# Altium schematic sheet MCU.SchDoc
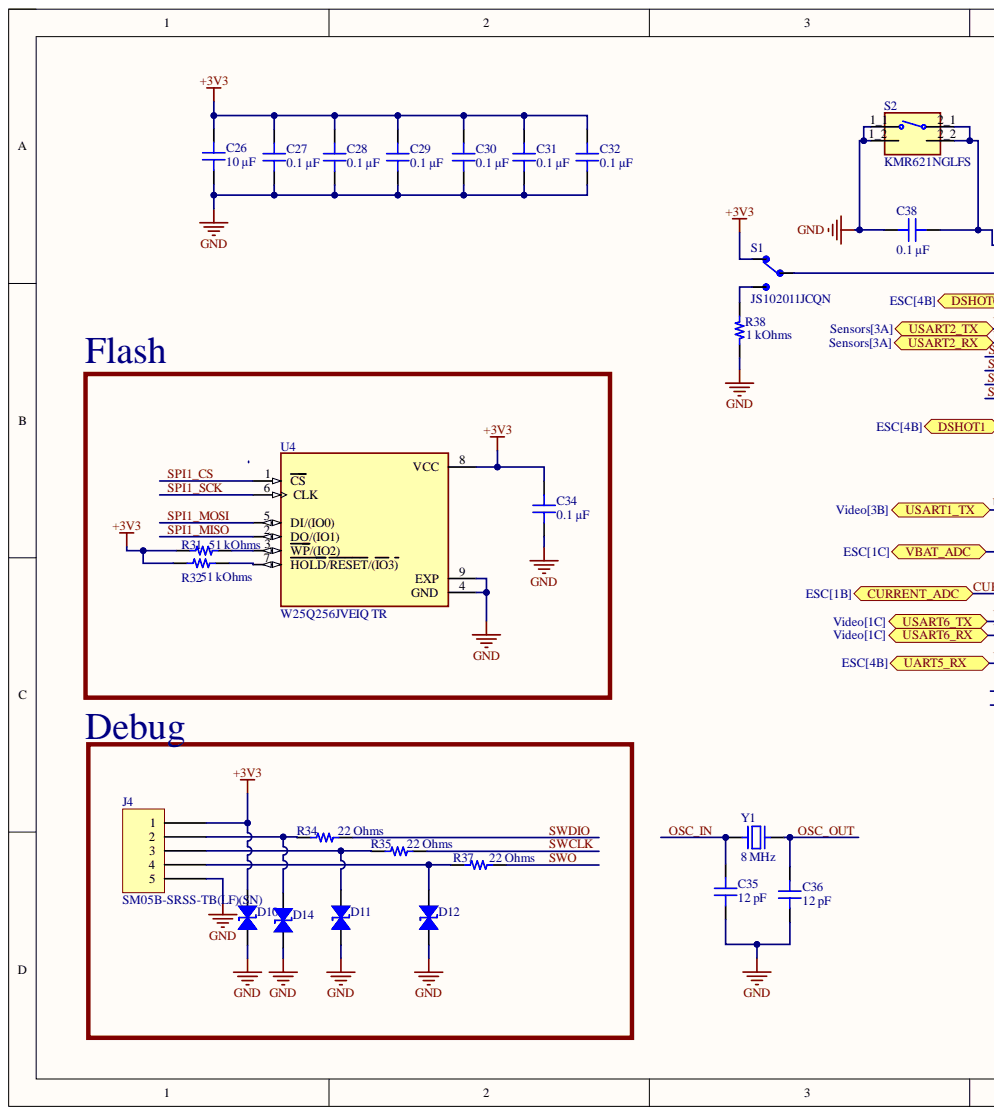
[diagram p0: MCU.SchDoc, left]
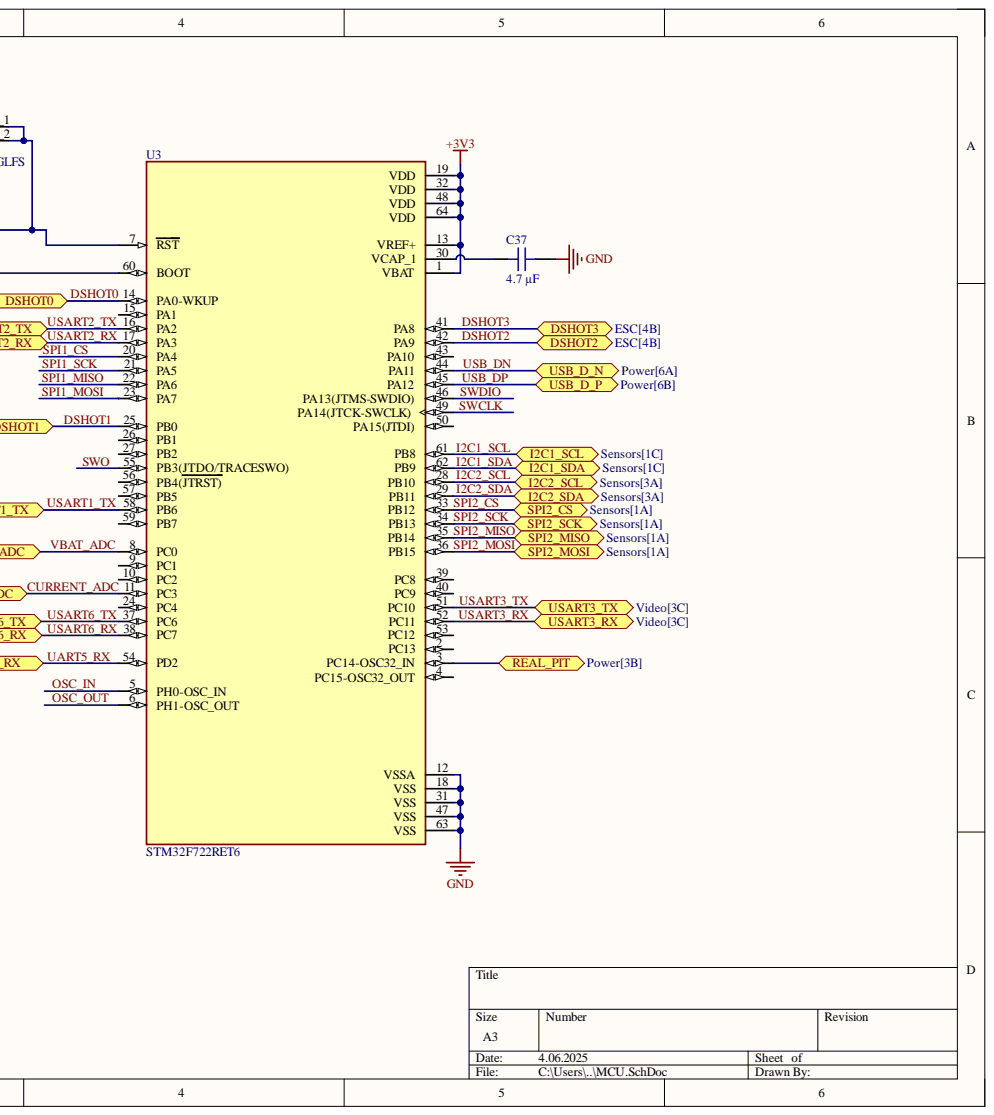
[diagram p1: MCU.SchDoc, right]

## Parts
C26  10 µF  [CAP 0402_1005]
C27  0.1 µF  [CAP 0402_1005]
C28  0.1 µF  [CAP 0402_1005]
C29  0.1 µF  [CAP 0402_1005]
C30  0.1 µF  [CAP 0402_1005]
C31  0.1 µF  [CAP 0402_1005]
C32  0.1 µF  [CAP 0402_1005]
C34  0.1 µF  [CAP 0603_1608]
C35  12 pF  [CAP 0402_1005]
C36  12 pF  [CAP 0402_1005]
C37  4.7 µF  [CAP 0402_1005]
C38  0.1 µF  [CAP 0402_1005]
D10  DESD5V0U1BA-7  [SOD323]  (value not drawn)
D11  DESD5V0U1BA-7  [SOD323]  (value not drawn)
D12  DESD5V0U1BA-7  [SOD323]  (value not drawn)
D14  DESD5V0U1BA-7  [SOD323]  (value not drawn)
J4  SM05B-SRSS-TB(LF)(SN)  [JST SM05B-SRSS-TB]
R31  51 kOhms  [RES 0603_1608]
R32  51 kOhms  [RES 0603_1608]
R34  22 Ohms  [YAGEO RES 0402_1005]
R35  22 Ohms  [YAGEO RES 0402_1005]
R37  22 Ohms  [YAGEO RES 0402_1005]
R38  1 kOhms  [YAGEO RES 0402_1005]
S1  JS102011JCQN  [JS102011JCQN]
S2  KMR621NGLFS  [KMR621NGLFS]
U3  STM32F722RET6  [STM32F722RET6]
U4  W25Q256JVEIQ TR  [W25Q256JVEIQ TR]
Y1  8 MHz  [NDK NX3225GD]

## Ports
CURRENT_ADC, DSHOT0, DSHOT1, DSHOT2, DSHOT3, I2C1_SCL, I2C1_SDA, I2C2_SCL, I2C2_SDA, REAL_PIT, SPI2_CS, SPI2_MISO, SPI2_MOSI, SPI2_SCK, UART5_RX, USART1_TX, USART2_RX, USART2_TX, USART3_RX, USART3_TX, USART6_RX, USART6_TX, USB_D_N, USB_D_P, VBAT_ADC

## Nets
+3V3: C26.2 C27.2 C28.2 C29.2 C30.2 C31.2 C32.2 C34.2 D10.2 J4.1 R31.2 R32.2 S1.1 U3.1(VBAT) U3.13(VREF+) U3.19(VDD) U3.32(VDD) U3.48(VDD) U3.64(VDD) U4.8(VCC)
GND: C26.1 C27.1 C28.1 C29.1 C30.1 C31.1 C32.1 C34.1 C35.1 C36.1 C37.2 C38.1 D10.1 D11.1 D12.1 D14.1 J4.5 R38.2 S2.1_1 S2.1_2 U3.12(VSSA) U3.18(VSS) U3.31(VSS) U3.47(VSS) U3.63(VSS) U4.4(GND) U4.9(EXP)
CURRENT_ADC: U3.11(PC3) ; port CURRENT_ADC
DSHOT0: U3.14(PA0-WKUP) ; port DSHOT0
DSHOT1: U3.25(PB0) ; port DSHOT1
DSHOT2: U3.42(PA9) ; port DSHOT2
DSHOT3: U3.41(PA8) ; port DSHOT3
I2C1_SCL: U3.61(PB8) ; port I2C1_SCL
I2C1_SDA: U3.62(PB9) ; port I2C1_SDA
I2C2_SCL: U3.28(PB10) ; port I2C2_SCL
I2C2_SDA: U3.29(PB11) ; port I2C2_SDA
OSC_IN: C35.2 U3.5(PH0-OSC_IN) Y1.1
OSC_OUT: C36.2 U3.6(PH1-OSC_OUT) Y1.2
REAL_PIT: U3.3(PC14-OSC32_IN) ; port REAL_PIT
SPI1_CS: U3.20(PA4) U4.1(CS)
SPI1_MISO: U3.22(PA6) U4.2(DO/(IO1))
SPI1_MOSI: U3.23(PA7) U4.5(DI/(IO0))
SPI1_SCK: U3.21(PA5) U4.6(CLK)
SPI2_CS: U3.33(PB12) ; port SPI2_CS
SPI2_MISO: U3.35(PB14) ; port SPI2_MISO
SPI2_MOSI: U3.36(PB15) ; port SPI2_MOSI
SPI2_SCK: U3.34(PB13) ; port SPI2_SCK
SWCLK: R35.2 U3.49(PA14(JTCK-SWCLK))
SWDIO: R34.2 U3.46(PA13(JTMS-SWDIO))
SWO: R37.2 U3.55(PB3(JTDO/TRACESWO))
UART5_RX: U3.54(PD2) ; port UART5_RX
USART1_TX: U3.58(PB6) ; port USART1_TX
USART2_RX: U3.17(PA3) ; port USART2_RX
USART2_TX: U3.16(PA2) ; port USART2_TX
USART3_RX: U3.52(PC11) ; port USART3_RX
USART3_TX: U3.51(PC10) ; port USART3_TX
USART6_RX: U3.38(PC7) ; port USART6_RX
USART6_TX: U3.37(PC6) ; port USART6_TX
USB_DN: U3.44(PA11) ; port USB_D_N
USB_DP: U3.45(PA12) ; port USB_D_P
VBAT_ADC: U3.8(PC0) ; port VBAT_ADC
NetC37_1: C37.1 U3.30(VCAP_1)
NetC38_2: C38.2 S2.2_1 S2.2_2 U3.7(RST)
NetD11_2: D11.2 J4.3 R35.1
NetD12_2: D12.2 J4.4 R37.1
NetD14_2: D14.2 J4.2 R34.1
NetR31_1: R31.1 U4.3(WP/(IO2))
NetR32_1: R32.1 U4.7(HOLD/RESET/(IO3))
NetR38_1: R38.1 S1.3
NetS1_2: S1.2 U3.60(BOOT)

Not connected: U3 2(PC13) 4(PC15-OSC32_OUT) 9(PC1) 10(PC2) 15(PA1) 24(PC4) 26(PB1) 27(PB2) 39(PC8) 40(PC9) 43(PA10) 50(PA15(JTDI)) 53(PC12) 56(PB4(JTRST)) 57(PB5) 59(PB7)

## Library data of the parts
C26 = CL05A106MQ5NUNC: CAP CER 10UF 6.3V X5R 0402; manufacturer: Samsung Electro-Mechanics; Database Table Name: Capacitors - Ceramic - 0402; Tolerance: ±20%; Applications: General Purpose; Capacitance: 10 µF; Component Kind: Standard; componentlink1description: Datasheet; componentlink1url: http://www.samsungsem.com/kr/support/product-search/mlcc/CL05A106MQ5NUNC.jsp; componentlink2description: DigiKey Link; componentlink2url: https://www.digikey.com/en/products/detail/samsung-electro-mechanics/CL05A106MQ5NUNC/3887109; Device Package: 0402 (1005 Metric); lastupdated: 9.02.2024 20:04:38; Library Name: Celestial Altium Library - altium_library.DbLib; Lifecycle Status: Active; Minimum Order: 1; Mounting Type: Surface Mount, MLCC; Operating Temperature: -55°C ~ 85°C; Package / Case: 0402 (1005 Metric); packaging: TapeAndReel; Part Number: CL05A106MQ5NUNC; Part Status: Active; partid: 1766; price: 0.03; series: CL; Size / Dimension: 0.039" L x 0.020" W (1.00mm x 0.50mm); Supplier 1: DigiKey; Supplier Part Number 1: 1276-1451-1-ND; Temperature Coefficient: X5R; Thickness (Max): 0.028" (0.70mm); value: 10 µF; Voltage - Rated: 6.3V
C27-C32 C38 = CC0402MRX5R5BB104: CAP CER 0.1UF 6.3V X5R 0402; manufacturer: Yageo; Database Table Name: Capacitors - Ceramic - 0402; Tolerance: ±20%; Applications: General Purpose; Capacitance: 0.1 µF; Component Kind: Standard; componentlink1description: Datasheet; componentlink1url: https://www.yageo.com/upload/media/product/productsearch/datasheet/mlcc/UPY-GPHC_X5R_4V-to-50V_25.pdf; componentlink2description: DigiKey Link; componentlink2url: https://www.digikey.com/en/products/detail/yageo/CC0402MRX5R5BB104/5883384; Device Package: 0402 (1005 Metric); lastupdated: 9.02.2024 20:04:37; Library Name: Celestial Altium Library - altium_library.DbLib; Lifecycle Status: Active; Minimum Order: 10; Mounting Type: Surface Mount, MLCC; Operating Temperature: -55°C ~ 85°C; Package / Case: 0402 (1005 Metric); packaging: CutTape; Part Number: CC0402MRX5R5BB104; Part Status: Active; partid: 1960; price: 0.01; series: CC; Size / Dimension: 0.039" L x 0.020" W (1.00mm x 0.50mm); Supplier 1: DigiKey; Supplier Part Number 1: 311-3818-1-ND; Temperature Coefficient: X5R; Thickness (Max): 0.022" (0.55mm); value: 0.1 µF; Voltage - Rated: 6.3V
C34 = CL10B104KB8NNNC: CAP CER 0.1UF 50V X7R 0603; manufacturer: Samsung Electro-Mechanics; Database Table Name: Capacitors - Ceramic - 0603; Tolerance: ±10%; Applications: General Purpose; Capacitance: 0.1 µF; Component Kind: Standard; componentlink1description: Datasheet; componentlink1url: http://www.samsungsem.com/kr/support/product-search/mlcc/CL10B104KB8NNNC.jsp; componentlink2description: DigiKey Link; componentlink2url: https://www.digikey.com/en/products/detail/samsung-electro-mechanics/CL10B104KB8NNNC/3886658; Device Package: 0603 (1608 Metric); lastupdated: 9.02.2024 20:10:20; Library Name: Celestial Altium Library - altium_library.DbLib; Lifecycle Status: Active; Minimum Order: 1; Mounting Type: Surface Mount, MLCC; Operating Temperature: -55°C ~ 125°C; Package / Case: 0603 (1608 Metric); packaging: TapeAndReel; Part Number: CL10B104KB8NNNC; Part Status: Active; partid: 9788; price: 0.01; series: CL; Size / Dimension: 0.063" L x 0.031" W (1.60mm x 0.80mm); Supplier 1: DigiKey; Supplier Part Number 1: 1276-1000-1-ND; Temperature Coefficient: X7R; Thickness (Max): 0.035" (0.90mm); value: 0.1 µF; Voltage - Rated: 50V
C35 C36 = C0402C120J8GAC7867: CAP CER 12PF 10V NP0 0402; manufacturer: KEMET; Database Table Name: Capacitors - Ceramic - 0402; Temperature Coefficient: C0G, NP0; Tolerance: ±5%; Applications: General Purpose; Capacitance: 12 pF; Component Kind: Standard; componentlink1description: Datasheet; componentlink1url: https://api.kemet.com/component-edge/download/datasheet/C0402C120J8GACTU.pdf; componentlink2description: DigiKey Link; componentlink2url: https://www.digikey.com/en/products/detail/kemet/C0402C120J8GAC7867/2196270; Device Package: 0402 (1005 Metric); Features: Low ESL; lastupdated: 9.02.2024 20:04:28; Library Name: Celestial Altium Library - altium_library.DbLib; Lifecycle Status: Active; Minimum Order: 1; Mounting Type: Surface Mount, MLCC; Operating Temperature: -55°C ~ 125°C; Package / Case: 0402 (1005 Metric); packaging: TapeAndReel; Part Number: C0402C120J8GAC7867; Part Status: Active; partid: 7556; price: 0.05; series: SMD Comm C0G; Size / Dimension: 0.039" L x 0.020" W (1.00mm x 0.50mm); Supplier 1: DigiKey; Supplier Part Number 1: 399-14417-1-ND; Thickness (Max): 0.022" (0.55mm); value: 12 pF; Voltage - Rated: 10V
C37 = C1005X5R0J475K050BC: CAP CER 4.7UF 6.3V X5R 0402; manufacturer: TDK Corporation; Database Table Name: Capacitors - Ceramic - 0402; Tolerance: ±10%; Applications: General Purpose; Capacitance: 4.7 µF; Component Kind: Standard; componentlink1description: Datasheet; componentlink1url: https://product.tdk.com/info/en/catalog/datasheets/mlcc_commercial_general_en.pdf?ref_disty=digikey; componentlink2description: DigiKey Link; componentlink2url: https://www.digikey.com/en/products/detail/tdk-corporation/C1005X5R0J475K050BC/2443425; Device Package: 0402 (1005 Metric); Features: Low ESL; lastupdated: 9.02.2024 20:04:37; Library Name: Celestial Altium Library - altium_library.DbLib; Lifecycle Status: Active; Minimum Order: 1; Mounting Type: Surface Mount, MLCC; Operating Temperature: -55°C ~ 85°C; Package / Case: 0402 (1005 Metric); packaging: TapeAndReel; Part Number: C1005X5R0J475K050BC; Part Status: Active; partid: 2194; price: 0.06; series: C; Size / Dimension: 0.039" L x 0.020" W (1.00mm x 0.50mm); Supplier 1: DigiKey; Supplier Part Number 1: 445-5947-1-ND; Temperature Coefficient: X5R; Thickness (Max): 0.026" (0.65mm); value: 4.7 µF; Voltage - Rated: 6.3V
D10-D12 D14 = DESD5V0U1BA-7: TVS DIODE 5VWM 7.2VC SOD323; Manufacturer: Diodes Incorporated; Bidirectional Channels: 1; Capacitance @ Frequency: 2.9pF @ 1MHz; Current - Peak Pulse (10/1000µs): 3A (8/20µs); Database Table Name: Diodes - TVS; Power Line Protection: No; Type: Zener; Voltage - Breakdown (Min): 5.5V; Voltage - Clamping (Max) @ Ipp: 7.2V (Typ); Voltage - Reverse Standoff (Typ): 5V (Max); Applications: General Purpose; Component Kind: Standard; ComponentLink1Description: Datasheet; ComponentLink1URL: https://www.diodes.com/assets/Datasheets/DESD5V0U1BA.pdf; ComponentLink2Description: DigiKey Link; ComponentLink2URL: https://www.digikey.com/en/products/detail/diodes-incorporated/DESD5V0U1BA-7/5371876; LastUpdated: 3.06.2024 20:22:28; Library Name: Celestial Altium Library - altium_library.DbLib; Lifecycle Status: Active; Minimum Order: 1; Mounting Type: Surface Mount; Operating Temperature: -65°C ~ 150°C (TJ); Package / Case: SC-76, SOD-323; Packaging: TapeAndReel; Part Number: DESD5V0U1BA-7; Part Status: Active; PartId: 9987; Price: 0.02; Series: -; Supplier 1: DigiKey; Supplier Device Package: SOD-323; Supplier Part Number 1: DESD5V0U1BA-7DICT-ND
J4 = SM05B-SRSS-TB(LF)(SN): CONN HEADER SMD R/A 5POS 1MM; Manufacturer: JST Sales America Inc.; Current Rating (Amps): 0.7A; Database Table Name: Connectors - Rectangular Headers Male Pins; Material Flammability Rating: UL94 V-0; Number of Positions: 5; Number of Positions Loaded: All; Pitch - Mating: 0.039" (1.00mm); Shrouding: Shrouded - 4 Wall; Style: Board to Cable/Wire; Voltage Rating: 50V; Component Kind: Standard; ComponentLink1Description: Datasheet; ComponentLink1URL: http://www.jst-mfg.com/product/pdf/eng/eSH.pdf; ComponentLink2Description: DigiKey Link; ComponentLink2URL: http://digikey.com/product-detail/en/jst-sales-america-inc/SM05B-SRSS-TB-LF-SN/455-1805-1-ND/926876; Connector Type: Header; Contact Finish - Mating: Tin; Contact Finish - Post: Tin; Contact Material: Copper Alloy; Contact Shape: Square; Contact Type: Male Pin; Fastening Type: Push-Pull; Features: Solder Retention; LastUpdated: 12.01.2020 23:42:04; Library Name: Celestial Altium Library - altium_library.DbLib; Lifecycle Status: Active; Minimum Order: 1; Mounting Type: Surface Mount, Right Angle; Number of Rows: 1; Operating Temperature: -25°C ~ 85°C; Packaging: TapeAndReel; Part Number: SM05B-SRSS-TB(LF)(SN); Part Status: Active; PartId: 63; Price: 0.32; Series: SR; Supplier 1: DigiKey; Supplier Part Number 1: 455-1805-1-ND; Termination: Solder; Insulation Color: Natural; Insulation Height: 0.114" (2.90mm); Insulation Material: Polyamide (PA), Nylon
R31 R32 = CRCW060351K0FKEAHP: RES SMD 51K OHM 1% 1/3W 0603; Manufacturer: Vishay Dale; Database Table Name: Resistors - Surface Mount - 0603; Power (Watts): 0.333W, 1/3W; Temperature Coefficient: ±100ppm/°C; Component Kind: Standard; ComponentLink1Description: Datasheet; ComponentLink1URL: https://www.vishay.com/docs/20043/crcwhpe3.pdf; ComponentLink2Description: DigiKey Link; ComponentLink2URL: https://www.digikey.com/en/products/detail/vishay-dale/CRCW060351K0FKEAHP/2226362; Composition: Thick Film; Device Package: 0603 (1608 Metric); Features: Automotive AEC-Q200, Pulse Withstanding; Height - Seated (Max): 0.022" (0.55mm); LastUpdated: 9.02.2024 20:41:01; Library Name: Celestial Altium Library - altium_library.DbLib; Lifecycle Status: Active; Minimum Order: 1; Number of Terminations: 2; Operating Temperature: -55°C ~ 155°C; Package / Case: 0603 (1608 Metric); Packaging: TapeAndReel; Part Number: CRCW060351K0FKEAHP; Part Status: Active; PartId: 71937; Price: 0.02; Ratings: AEC-Q200; Resistance: 51 kOhms; Series: CRCW-HP; Size / Dimension: 0.063" L x 0.033" W (1.60mm x 0.85mm); Supplier 1: DigiKey; Supplier Device Package: 0603; Supplier Part Number 1: 541-CRCW060351K0FKEAHPCT-ND; Tolerance: ±1%; Value: 51 kOhms
R34 R35 R37 = AC0402JR-0722RL: RES SMD 22 OHM 5% 1/16W 0402; Manufacturer: Yageo; Database Table Name: Resistors - Surface Mount - 0402; Power (Watts): 0.063W, 1/16W; Temperature Coefficient: ±100ppm/°C; Component Kind: Standard; ComponentLink1Description: Datasheet; ComponentLink1URL: https://www.yageo.com/upload/media/product/productsearch/datasheet/rchip/PYu-AC_51_RoHS_L_7.pdf; ComponentLink2Description: DigiKey Link; ComponentLink2URL: https://www.digikey.com/en/products/detail/yageo/AC0402JR-0722RL/5895625; Composition: Thick Film; Device Package: 0402 (1005 Metric); Features: Automotive AEC-Q200, Moisture Resistant; Height - Seated (Max): 0.015" (0.37mm); LastUpdated: 25.07.2024 09:18:12; Library Name: Celestial Altium Library - altium_library.DbLib; Lifecycle Status: Active; Minimum Order: 1; Number of Terminations: 2; Operating Temperature: -55°C ~ 155°C; Package / Case: 0402 (1005 Metric); Packaging: CutTape; Part Number: AC0402JR-0722RL; Part Status: Active; PartId: 15641; Price: 0.01; Ratings: AEC-Q200; Resistance: 22 Ohms; Series: AC; Size / Dimension: 0.039" L x 0.020" W (1.00mm x 0.50mm); Supplier 1: DigiKey; Supplier Device Package: 0402; Supplier Part Number 1: YAG3533CT-ND; Tolerance: ±5%; Value: 22 Ohms
R38 = RT0402BRE071KL: RES SMD 1K OHM 0.1% 1/16W 0402; Manufacturer: Yageo; Database Table Name: Resistors - Surface Mount - 0402; Power (Watts): 0.063W, 1/16W; Temperature Coefficient: ±50ppm/°C; Component Kind: Standard; ComponentLink1Description: Datasheet; ComponentLink1URL: https://www.yageo.com/upload/media/product/productsearch/datasheet/rchip/PYu-RT_1-to-0.01_RoHS_L_11.pdf; ComponentLink2Description: DigiKey Link; ComponentLink2URL: https://www.digikey.com/en/products/detail/yageo/RT0402BRE071KL/1069571; Composition: Thin Film; Device Package: 0402 (1005 Metric); Height - Seated (Max): 0.014" (0.35mm); LastUpdated: 25.07.2024 09:18:18; Library Name: Celestial Altium Library - altium_library.DbLib; Lifecycle Status: Active; Minimum Order: 1; Number of Terminations: 2; Operating Temperature: -55°C ~ 155°C; Package / Case: 0402 (1005 Metric); Packaging: TapeAndReel; Part Number: RT0402BRE071KL; Part Status: Active; PartId: 3163; Price: 0.04; Resistance: 1 kOhms; Series: RT; Size / Dimension: 0.039" L x 0.020" W (1.00mm x 0.50mm); Supplier 1: DigiKey; Supplier Device Package: 0402; Supplier Part Number 1: YAG2306CT-ND; Tolerance: ±0.1%; Value: 1 kOhms
S1 = JS102011JCQN: SWITCH SLIDE SPDT 300MA 6V; Manufacturer: C&K; Actuator Length: 2.00mm; Actuator Material: Polyamide (PA46), Nylon 4/6; Actuator Type: Standard; Contact Timing: Not Specified; Current Rating (Amps): 300mA (DC); Electrical Life: 5,000 Cycles; Switch Function: On-On; Circuit: SPDT; Component Kind: Standard; ComponentLink1Description: Datasheet; ComponentLink1URL: https://www.ckswitches.com/media/1422/js.pdf; ComponentLink2Description: Digikey Link; ComponentLink2URL: https://www.digikey.com/en/products/detail/c-k/JS102011JCQN/6137628; Contact Finish: Silver; Contact Material: Copper Alloy; Library Name: PCB_Lib.IntLib; Mounting Type: Surface Mount; Operating Temperature: -40°C ~ 85°C; Switch Travel: 2.00mm; Termination Style: J Lead; Voltage Rating - DC: 6V
S2 = KMR621NGLFS: SWITCH TACTILE SPST-NO 0.05A 32V; Manufacturer: C&K; Actuator Height off PCB, Vertical: 1.90mm; Actuator Orientation: Top Actuated; Actuator Type: Standard; Contact Rating @ Voltage: 0.05A @ 32VDC; Mechanical Life: 200,000 Cycles; Operating Force: 200gf; Outline: 4.20mm x 2.80mm; Switch Function: Off-Mom; Illumination: Non-Illuminated; Ingress Protection: IP67 - Dust Tight, Waterproof; Circuit: SPST-NO; Component Kind: Standard; ComponentLink1Description: Datasheet; ComponentLink1URL: https://www.ckswitches.com/media/1907/kmr6.pdf; ComponentLink2Description: Digikey Link; ComponentLink2URL: https://www.digikey.com/en/products/detail/c-k/KMR621NG-LFS/6035350; Library Name: PCB_Lib.IntLib; Mounting Type: Surface Mount; Operating Temperature: -40°C ~ 85°C; Termination Style: Gull Wing
U3 = STM32F722RET6: ARM® Cortex®-M7 STM32F7 Microcontroller IC 32-Bit Single-Core 216MHz 512KB (512K x 8) FLASH 64-LQFP (10x10); Manufacturer: STMicroelectronics; Connectivity: CANbus, I2C, IrDA, LINbus, MMC/SD, QSPI, SAI, SPI, UART/USART, USB; Data Converters: A/D 16x12b; D/A 2x12b; Number of I/O: 50; Oscillator Type: Internal; Peripherals: Brown-out Detect/Reset, DMA, I2S, POR, PWM, WDT; RAM Size: 256K x 8; Voltage - Supply (Vcc/Vdd): 1.7V ~ 3.6V; Component Kind: Standard; ComponentLink1Description: Datasheet; ComponentLink1URL: https://www.st.com/content/ccc/resource/technical/document/datasheet/group3/d6/11/13/15/f4/38/49/79/DM00330506/files/DM00330506.pdf/jcr:content/translations/en.DM00330506.pdf; ComponentLink2Description: Digikey Link; ComponentLink2URL: https://www.digikey.com/en/products/detail/stmicroelectronics/STM32F722RET6/6621769; Core Processor: ARM® Cortex®-M7; Core Size: 32-Bit Single-Core; Library Name: PCB_Lib.IntLib; Operating Temperature: -40°C ~ 85°C (TA); Program Memory Size: 512KB (512K x 8); Program Memory Type: FLASH; Speed: 216MHz; Supplier Device Package: 64-LQFP (10x10)
U4 = W25Q256JVEIQ TR: FLASH - NOR Memory IC 256Mbit SPI - Quad I/O 133 MHz 8-WSON (8x6); Manufacturer: Winbond Electronics; Clock Frequency: 133 MHz; Memory Organization: 32M x 8; Memory Type: Non-Volatile; Voltage - Supply: 2.7V ~ 3.6V; Write Cycle Time - Word, Page: 3ms; Component Kind: Standard; ComponentLink1Description: Datasheet; ComponentLink1URL: https://www.winbond.com/resource-files/w25q256jv%20spi%20revg%2008032017.pdf; ComponentLink2Description: Digikey Link; ComponentLink2URL: https://www.digikey.com/en/products/detail/winbond-electronics/w25q256jveiq-tr/5803969; Library Name: PCB_Lib.IntLib; Memory Format: FLASH; Memory Size: 256Mbit; Memory Interface: SPI - Quad I/O; Mounting Type: Surface Mount; Operating Temperature: -40°C ~ 85°C (TA); Supplier Device Package: 8-WSON (8x6); Technology: FLASH - NOR
Y1 = NX3225GD-8MHZ-STD-CRA-3: CRYSTAL 8.0000MHZ 8PF SMD; Manufacturer: NDK America, Inc.; Database Table Name: Oscillators - Crystals; ESR (Equivalent Series Resistance): 500 Ohms; Frequency Stability: ±150ppm; Frequency Tolerance: ±50ppm; Operating Mode: Fundamental; Component Kind: Standard; ComponentLink1Description: Datasheet; ComponentLink1URL: http://www.ndk.com/images/products/catalog/c_NX3225GD-STD-CRA-3_e.pdf; ComponentLink2Description: DigiKey Link; ComponentLink2URL: https://www.digikey.com/en/products/detail/ndk-america-inc/NX3225GD-8MHZ-STD-CRA-3/3125637; Frequency: 8 MHz; Height - Seated (Max): 0.039" (1.00mm); LastUpdated: 3.06.2024 20:17:11; Library Name: Celestial Altium Library - altium_library.DbLib; Lifecycle Status: Active; Load Capacitance: 8pF; Minimum Order: 1; Mounting Type: Surface Mount; Operating Temperature: -40°C ~ 150°C; Package / Case: 2-SMD, No Lead; Packaging: TapeAndReel; Part Number: NX3225GD-8MHZ-STD-CRA-3; Part Status: Active; PartId: 744; Price: 0.48; Ratings: AEC-Q200; Series: NX3225GD; Size / Dimension: 0.126" L x 0.098" W (3.20mm x 2.50mm); Supplier 1: DigiKey; Supplier Part Number 1: 644-1178-1-ND; Type: MHz Crystal; Value: 8 MHz
